# Altium schematic sheet bean2.SchDoc
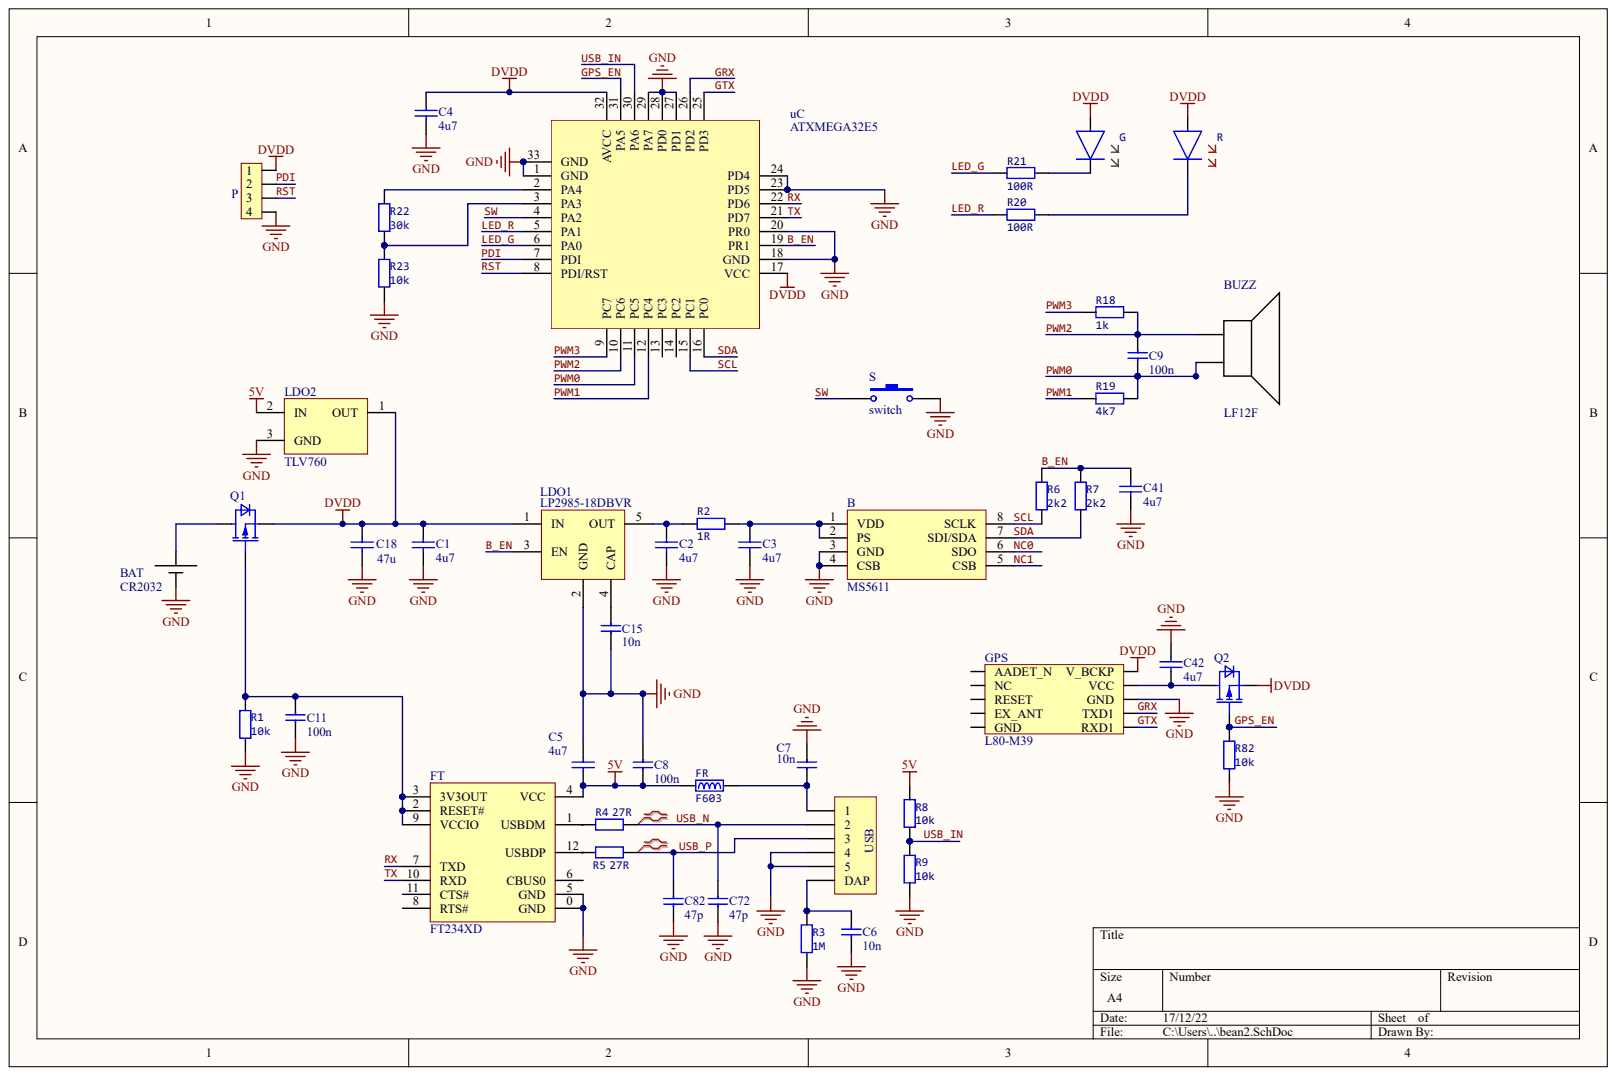
[diagram p0: bean2.SchDoc]

## Parts
B  MS5611
BAT  CR2032
BUZZ  LF12F
C1  4u7
C2  4u7
C3  4u7
C4  4u7
C5  4u7
C6  10n
C7  10n
C8  100n
C9  100n
C11  100n
C15  10n
C18  value 47u  (hidden comment: C1206)
C41  4u7
C42  4u7
C72  47p
C82  47p
FR  F603
FT  FT234XD
G  LED  (value not drawn)
GPS  L80-M39
LDO1  LP2985-18DBVR
LDO2  TLV760
P  PROG  (value not drawn)
Q1  PMZB1200UPE  (value not drawn)
Q2  PMZB1200UPE  (value not drawn)
R  LED  (value not drawn)
R1  10k
R2  1R
R3  1M
R4  27R
R5  27R
R6  2k2
R7  2k2
R8  10k
R9  10k
R18  1k
R19  4k7
R20  100R
R21  100R
R22  30k
R23  10k
R82  10k
S  switch
uC  ATXMEGA32E5
USB  ZX62R-B-5P  (value not drawn)

## Nets
5V: C5.1 C8.1 FR.2 FT.4(VCC) LDO2.2 R8.2
DVDD: C1.2 C4.2 C18.2 G.1 GPS.5(V_BCKP) LDO1.1(IN) LDO2.1 P.1 Q1.2 Q2.2 R.1 uC.17(VCC) uC.32(AVCC)
GND: B.3(GND) B.4(CSB) BAT.2 C1.1 C2.1 C3.1 C4.1 C5.2 C6.1 C7.2 C8.2 C11.2 C15.1 C18.1 C41.1 C42.2 C72.1 C82.1 FT.0(GND) FT.5(GND) GPS.3(GND) LDO1.2(GND) LDO2.3 P.4 R1.2 R3.1 R9.1 R23.2 R82.1 S.1 uC.1(GND) uC.18(GND) uC.20(PR0) uC.23(PD5) uC.24(PD4) uC.27(PD1) uC.28(PD0) uC.29(PA7) uC.33(GND) USB.4 USB.5
B_EN: C41.2 LDO1.3(EN) R6.2 R7.2 uC.19(PR1)
GPS_EN: Q2.1 R82.2 uC.31(PA5)
GRX: GPS.2(TXD1) uC.26(PD2)
GTX: GPS.1(RXD1) uC.25(PD3)
LED_G: R21.2 uC.6(PA0)
LED_R: R20.2 uC.5(PA1)
NC0: B.6(SDO)
NC1: B.5(CSB)
PDI: P.2 uC.7(PDI)
PWM0: BUZZ.2 C9.1 R19.1 uC.11(PC5)
PWM1: R19.2 uC.12(PC4)
PWM2: BUZZ.1 C9.2 R18.1 uC.10(PC6)
PWM3: R18.2 uC.9(PC7)
RST: P.3 uC.8(PDI/RST)
RX: FT.7(TXD) uC.22(PD6)
SCL: B.8(SCLK) R6.1 uC.15(PC1)
SDA: B.7(SDI/SDA) R7.1 uC.16(PC0)
SW: S.2 uC.4(PA2)
TX: FT.10(RXD) uC.21(PD7)
USB_IN: R8.1 R9.2 uC.30(PA6)
USB_N: C72.2 R4.1 USB.2
USB_P: C82.2 R5.1 USB.3
NetB_1: B.1(VDD) B.2(PS) C3.2 R2.1
NetBAT_1: BAT.1 Q1.3
NetC2_2: C2.2 LDO1.5(OUT) R2.2
NetC6_2: C6.2 R3.2 USB.0(DAP)
NetC7_1: C7.1 FR.1 USB.1
NetC11_1: C11.1 FT.2(RESET#) FT.3(3V3OUT) FT.9(VCCIO) Q1.1 R1.1
NetC15_2: C15.2 LDO1.4(CAP)
NetC42_1: C42.1 GPS.4(VCC) Q2.3
NetFT_1: FT.1(USBDM) R4.2
NetFT_12: FT.12(USBDP) R5.2
NetG_2: G.2 R21.1
NetR20_1: R.2 R20.1
NetR22_1: R22.1 uC.2(PA4)
NetR22_2: R22.2 R23.1 uC.3(PA3)

Not connected: FT 6(CBUS0) 8(RTS#) 11(CTS#); GPS 8(AADET_N) 9(NC) 10(RESET) 11(EX_ANT) 12(GND); uC 13(PC3) 14(PC2)

## Library data of the parts
C6-C9 C11 C15 C72 C82 = (no symbol name): Part Number: C0402-12p; Note: 50V; Artikkelnr Noca: 20029159; Artikkelnr Simpro: N.A.; Manufacturer: *; Manufacturer Part Number: *; Datasheet: *; LatestRevisionDate: 2011-05-11; Pin Count: 2; Published: 2009-05-08; Supplier: *; Supplier part number: *; 2nd source Supplier: *; 2nd source part number: *; Footprint Doc: 0402; Publisher: Nordic Semiconductor
  C6 C7 C15: Value: 10n
  C8 C9 C11: Value: 100n
  C72 C82: Value: 47p
Q1 Q2 = (no symbol name): Manufacturer: NXP; RoHS: YES; Supplier 1: Farnell; Supplier Part Number 1: 2069557
B BAT BUZZ C1-C5 C18 C41 C42 FR FT G GPS LDO1 LDO2 P R R1-R9 R18-R23 R82 S uC USB = (no symbol name)
  BUZZ: Supplier 2: sos; Supplier Part Number 2: 84683
  C1-C5 C41 C42: LatestRevisionDate: 29-May-2009; LatestRevisionNote: IPC-7351 Footprint Added.; PackageDescription: Chip Capacitor; Value: 4u7
  C18: LatestRevisionDate: 29-May-2009; LatestRevisionNote: IPC-7351 Footprint Added.; PackageDescription: Chip Capacitor
  FR: Published: 20.5.2013; Manufacturer: *; Supplier 1: Farnell; Supplier Part Number 1: 1463451; Publisher: Martin Jagelka
  G: Manufacturer: *; ComponentLink1Description: Datasheet; Supplier 1: Farnell; Supplier Part Number 1: 2099239
  LDO1: Supplier 1: Farnell; Supplier Part Number 1: 2342572
  R: Manufacturer: *; ComponentLink1Description: Datasheet; Supplier 1: Farnell; Supplier Part Number 1: 2099236
  R1 R8 R9 R23 R82: Value: 10k; Manufacturer: *; ComponentLink1Description: Datasheet
  R2: Value: 1R; Manufacturer: *; ComponentLink1Description: Datasheet
  R3: Value: 1M; Manufacturer: *; ComponentLink1Description: Datasheet
  R4 R5: Value: 27R; Manufacturer: *; ComponentLink1Description: Datasheet
  R6 R7: Value: 2k2; Manufacturer: *; ComponentLink1Description: Datasheet
  R18: Value: 1k; Manufacturer: *; ComponentLink1Description: Datasheet
  R19: Value: 4k7; Manufacturer: *; ComponentLink1Description: Datasheet
  R20 R21: Value: 100R; Manufacturer: *; ComponentLink1Description: Datasheet
  R22: Value: 30k; Manufacturer: *; ComponentLink1Description: Datasheet
  USB: Supplier 1: Farnell; Supplier Part Number 1: 2554983
